# Altium schematic sheet Flash4DE1_SoC.SchDoc(Flash4DE1_SoC)
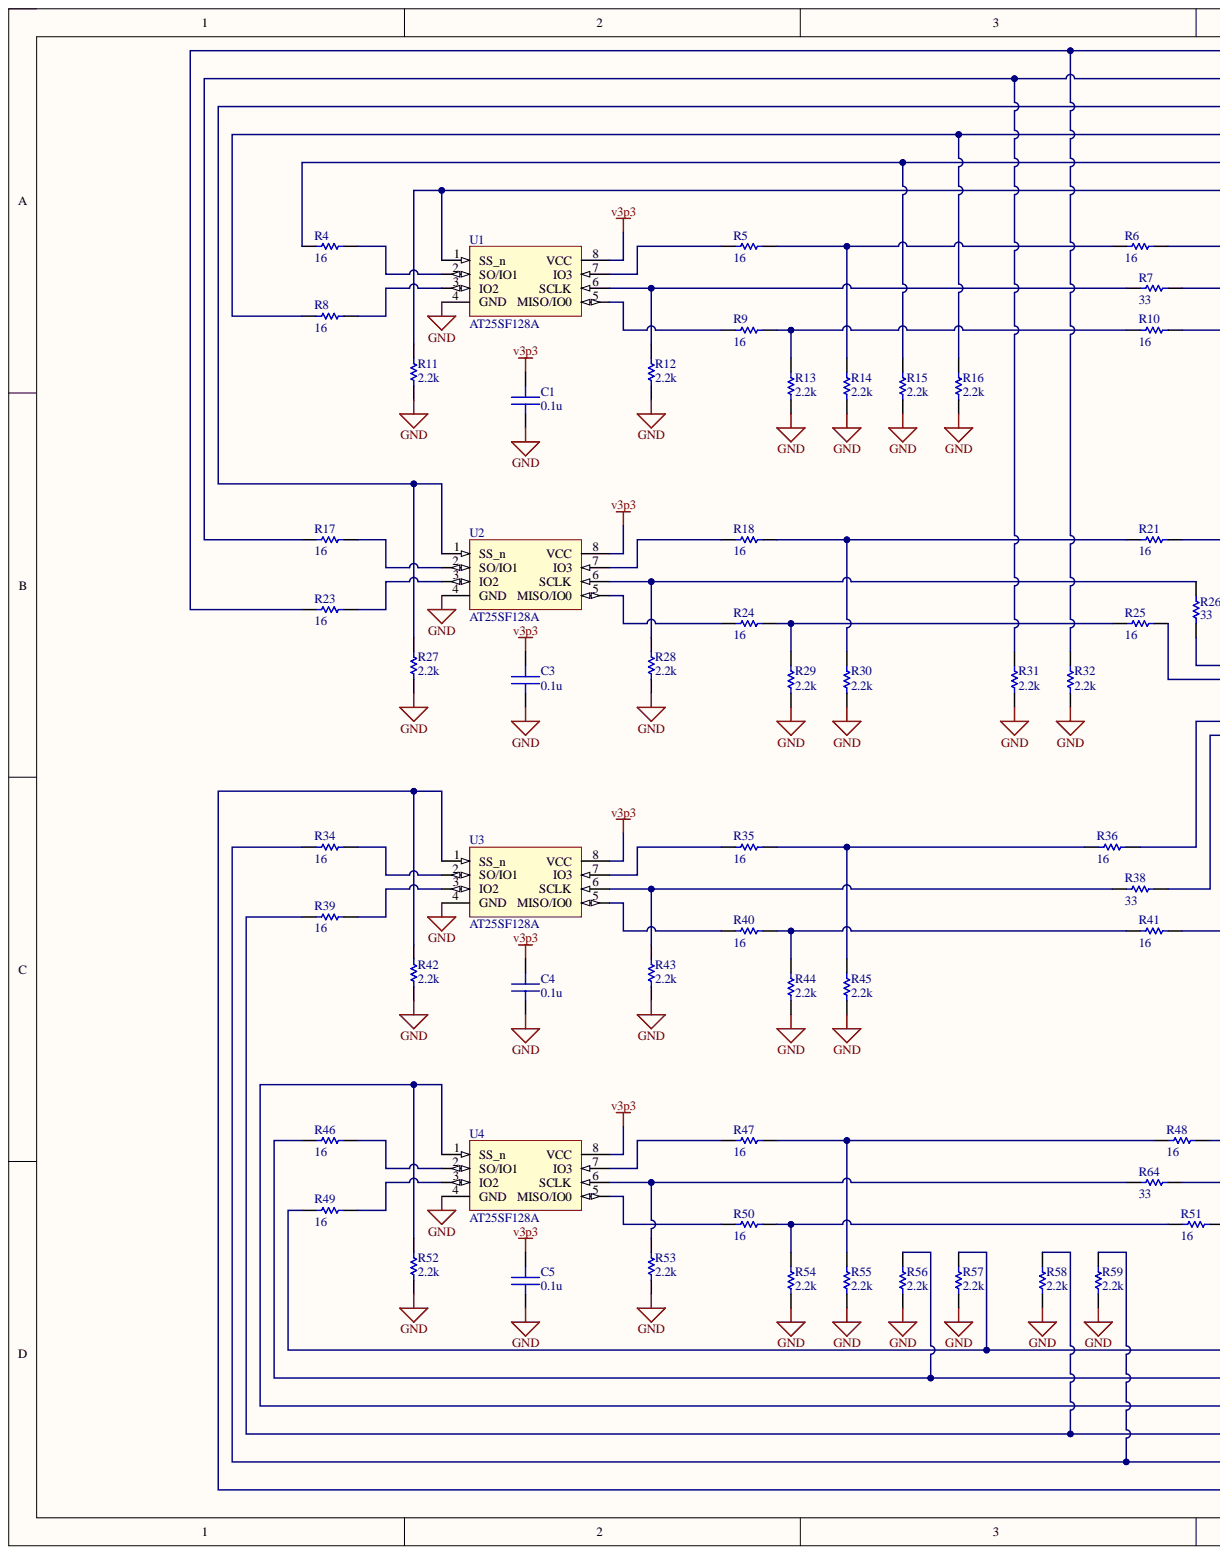
[diagram p0: Flash4DE1_SoC.SchDoc, left]
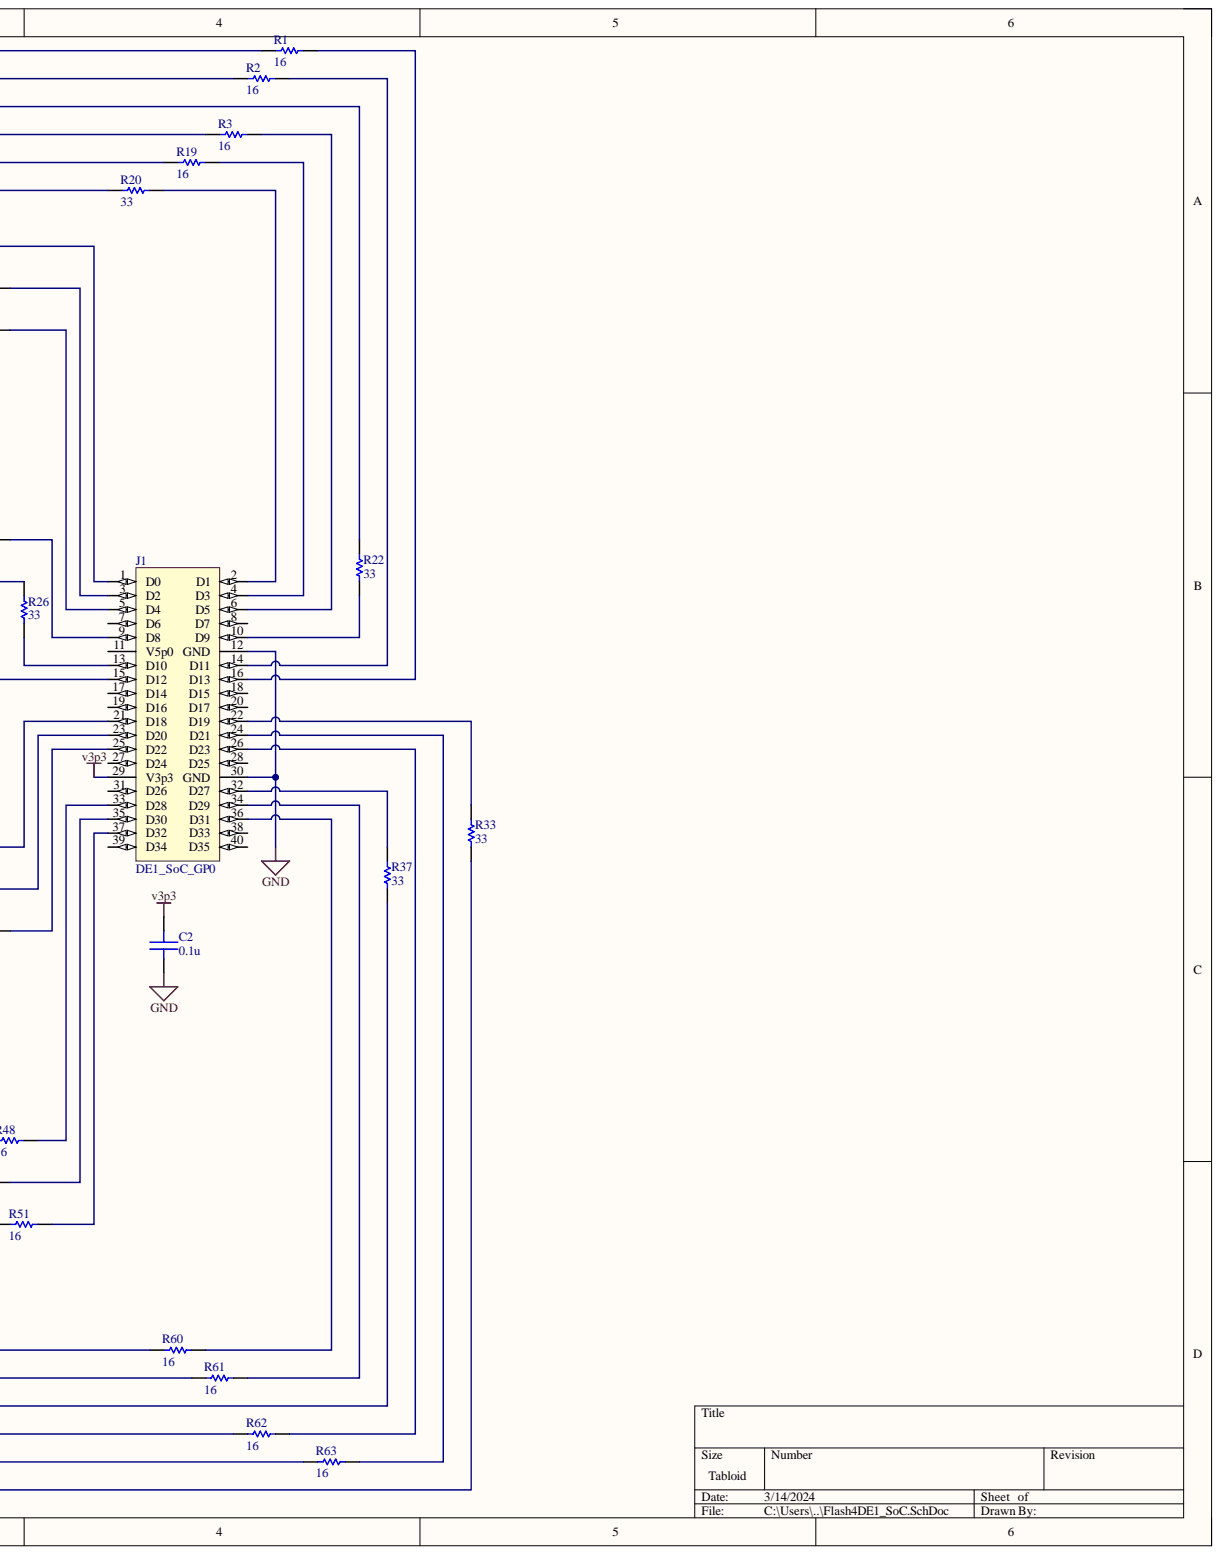
[diagram p1: Flash4DE1_SoC.SchDoc, right]

## Parts
C1  0.1u  [CAPC0603(1608)100_N]
C2  0.1u  [CAPC0603(1608)100_N]
C3  0.1u  [CAPC0603(1608)100_N]
C4  0.1u  [CAPC0603(1608)100_N]
C5  0.1u  [CAPC0603(1608)100_N]
J1  DE1_SoC_GP0  [DE1_SoC_GP40]
R1  16  [RESC0603(1608)_N]
R2  16  [RESC0603(1608)_N]
R3  16  [RESC0603(1608)_N]
R4  16  [RESC0603(1608)_N]
R5  16  [RESC0603(1608)_N]
R6  16  [RESC0603(1608)_N]
R7  33  [RESC0603(1608)_N]
R8  16  [RESC0603(1608)_N]
R9  16  [RESC0603(1608)_N]
R10  16  [RESC0603(1608)_N]
R11  2.2k  [RESC0603(1608)_N]
R12  2.2k  [RESC0603(1608)_N]
R13  2.2k  [RESC0603(1608)_N]
R14  2.2k  [RESC0603(1608)_N]
R15  2.2k  [RESC0603(1608)_N]
R16  2.2k  [RESC0603(1608)_N]
R17  16  [RESC0603(1608)_N]
R18  16  [RESC0603(1608)_N]
R19  16  [RESC0603(1608)_N]
R20  33  [RESC0603(1608)_N]
R21  16  [RESC0603(1608)_N]
R22  33  [RESC0603(1608)_N]
R23  16  [RESC0603(1608)_N]
R24  16  [RESC0603(1608)_N]
R25  16  [RESC0603(1608)_N]
R26  33  [RESC0603(1608)_N]
R27  2.2k  [RESC0603(1608)_N]
R28  2.2k  [RESC0603(1608)_N]
R29  2.2k  [RESC0603(1608)_N]
R30  2.2k  [RESC0603(1608)_N]
R31  2.2k  [RESC0603(1608)_N]
R32  2.2k  [RESC0603(1608)_N]
R33  33  [RESC0603(1608)_N]
R34  16  [RESC0603(1608)_N]
R35  16  [RESC0603(1608)_N]
R36  16  [RESC0603(1608)_N]
R37  33  [RESC0603(1608)_N]
R38  33  [RESC0603(1608)_N]
R39  16  [RESC0603(1608)_N]
R40  16  [RESC0603(1608)_N]
R41  16  [RESC0603(1608)_N]
R42  2.2k  [RESC0603(1608)_N]
R43  2.2k  [RESC0603(1608)_N]
R44  2.2k  [RESC0603(1608)_N]
R45  2.2k  [RESC0603(1608)_N]
R46  16  [RESC0603(1608)_N]
R47  16  [RESC0603(1608)_N]
R48  16  [RESC0603(1608)_N]
R49  16  [RESC0603(1608)_N]
R50  16  [RESC0603(1608)_N]
R51  16  [RESC0603(1608)_N]
R52  2.2k  [RESC0603(1608)_N]
R53  2.2k  [RESC0603(1608)_N]
R54  2.2k  [RESC0603(1608)_N]
R55  2.2k  [RESC0603(1608)_N]
R56  2.2k  [RESC0603(1608)_N]
R57  2.2k  [RESC0603(1608)_N]
R58  2.2k  [RESC0603(1608)_N]
R59  2.2k  [RESC0603(1608)_N]
R60  16  [RESC0603(1608)_N]
R61  16  [RESC0603(1608)_N]
R62  16  [RESC0603(1608)_N]
R63  16  [RESC0603(1608)_N]
R64  33  [RESC0603(1608)_N]
U1  AT25SF128A  [SOIC8_Wide]
U2  AT25SF128A  [SOIC8_Wide]
U3  AT25SF128A  [SOIC8_Wide]
U4  AT25SF128A  [SOIC8_Wide]

## Nets
GND: C1.2 C2.2 C3.2 C4.2 C5.2 J1.12(GND) J1.30(GND) R11.2 R12.2 R13.2 R14.2 R15.2 R16.2 R27.2 R28.2 R29.2 R30.2 R31.2 R32.2 R42.2 R43.2 R44.2 R45.2 R52.2 R53.2 R54.2 R55.2 R56.2 R57.2 R58.2 R59.2 U1.4(GND) U2.4(GND) U3.4(GND) U4.4(GND)
v3p3: C1.1 C2.1 C3.1 C4.1 C5.1 J1.29(V3p3) U1.8(VCC) U2.8(VCC) U3.8(VCC) U4.8(VCC)
NetJ1_1: J1.1(D0) R6.1
NetJ1_2: J1.2(D1) R20.1
NetJ1_3: J1.3(D2) R7.1
NetJ1_4: J1.4(D3) R19.1
NetJ1_5: J1.5(D4) R10.1
NetJ1_6: J1.6(D5) R3.1
NetJ1_9: J1.9(D8) R21.1
NetJ1_10: J1.10(D9) R22.1
NetJ1_13: J1.13(D10) R26.1
NetJ1_14: J1.14(D11) R2.1
NetJ1_15: J1.15(D12) R25.1
NetJ1_16: J1.16(D13) R1.1
NetJ1_21: J1.21(D18) R36.1
NetJ1_22: J1.22(D19) R33.1
NetJ1_23: J1.23(D20) R38.1
NetJ1_24: J1.24(D21) R63.1
NetJ1_25: J1.25(D22) R41.1
NetJ1_26: J1.26(D23) R62.1
NetJ1_32: J1.32(D27) R37.1
NetJ1_33: J1.33(D28) R48.1
NetJ1_34: J1.34(D29) R61.1
NetJ1_35: J1.35(D30) R64.1
NetJ1_36: J1.36(D31) R60.1
NetJ1_37: J1.37(D32) R51.1
NetR1_2: R1.2 R23.2 R32.1
NetR2_2: R2.2 R17.2 R31.1
NetR3_2: R3.2 R8.2 R16.1
NetR4_1: R4.1 U1.2(SO/IO1)
NetR4_2: R4.2 R15.1 R19.2
NetR5_1: R5.1 R6.2 R14.1
NetR5_2: R5.2 U1.7(IO3)
NetR7_2: R7.2 R12.1 U1.6(SCLK)
NetR8_1: R8.1 U1.3(IO2)
NetR9_1: R9.1 R10.2 R13.1
NetR9_2: R9.2 U1.5(MISO/IO0)
NetR11_1: R11.1 R20.2 U1.1(SS_n)
NetR17_1: R17.1 U2.2(SO/IO1)
NetR18_1: R18.1 R21.2 R30.1
NetR18_2: R18.2 U2.7(IO3)
NetR22_2: R22.2 R27.1 U2.1(SS_n)
NetR23_1: R23.1 U2.3(IO2)
NetR24_1: R24.1 R25.2 R29.1
NetR24_2: R24.2 U2.5(MISO/IO0)
NetR26_2: R26.2 R28.1 U2.6(SCLK)
NetR33_2: R33.2 R42.1 U3.1(SS_n)
NetR34_1: R34.1 U3.2(SO/IO1)
NetR34_2: R34.2 R59.1 R63.2
NetR35_1: R35.1 R36.2 R45.1
NetR35_2: R35.2 U3.7(IO3)
NetR37_2: R37.2 R52.1 U4.1(SS_n)
NetR38_2: R38.2 R43.1 U3.6(SCLK)
NetR39_1: R39.1 U3.3(IO2)
NetR39_2: R39.2 R58.1 R62.2
NetR40_1: R40.1 R41.2 R44.1
NetR40_2: R40.2 U3.5(MISO/IO0)
NetR46_1: R46.1 U4.2(SO/IO1)
NetR46_2: R46.2 R56.1 R61.2
NetR47_1: R47.1 R48.2 R55.1
NetR47_2: R47.2 U4.7(IO3)
NetR49_1: R49.1 U4.3(IO2)
NetR49_2: R49.2 R57.1 R60.2
NetR50_1: R50.1 R51.2 R54.1
NetR50_2: R50.2 U4.5(MISO/IO0)
NetR53_1: R53.1 R64.2 U4.6(SCLK)

Not connected: J1 7(D6) 8(D7) 11(V5p0) 17(D14) 18(D15) 19(D16) 20(D17) 27(D24) 28(D25) 31(D26) 38(D33) 39(D34) 40(D35)

## Library data of the parts
C1-C5 = C0603_0p1u: C0603_0p1u 50V X7R; Manufacturer: KEMET; Manufacturer PN: C0603C104K5RACTU; Substitutions: Any 50V X7R; Component Kind: Standard; Library Name: MyCapacitors.SchLib; Supplier 1: Mouser; Supplier Part Number 1: 80-C0603C104K5R; value: 0.1u
J1 = DE1_SoC_GP0: 40-pin General Purpose I/O connector on DE1 SoC board; Manufacturer: SparkFun; Manufacturer PN: PRT-16764; Component Kind: Standard; Library Name: EricsSymbols.SchLib
R1-R6 R8-R10 R17-R19 R21 R23-R25 R34-R36 R39-R41 R46-R51 R60-R63 = R0603_16: Manufacturer: Yageo; Manufacturer PN: RC0603FR-0716RL; Substitutions: Any 1% or better; Component Kind: Standard; Description: R0603_16; Library Name: MyResistors.SchLib; Supplier 1: Digi-Key; Supplier Part Number 1: 311-10.0HRCT-ND; value: 16
R7 R20 R22 R26 R33 R37 R38 R64 = R0603_33: Manufacturer: Panasonic; Manufacturer PN: ERJ-3EKF33R0V; Substitutions: Any 1% or better; Component Kind: Standard; Description: R0603_33; Library Name: MyResistors.SchLib; Supplier 1: Digi-Key; Supplier Part Number 1: P33.0HDKR-ND; value: 33
R11-R16 R27-R32 R42-R45 R52-R59 = R0603_2p2k: Manufacturer: Panasonic; Manufacturer PN: ERJ-3EKF2201V; Substitutions: Any 1% or better; Component Kind: Standard; Description: R0603_2p2k; Library Name: MyResistors.SchLib; Supplier 1: Digi-Key; Supplier Part Number 1: P2.20KHCT-ND; value: 2.2k
U1-U4 = AT25SF128A: 16M byte Flash in SOIC-8 with Quad SPI interface; Component Kind: Standard; Library Name: EricsSymbols.SchLib
